# Altium schematic sheet Full-H Bridge_D.SchDoc("Full-H Bridge_D")
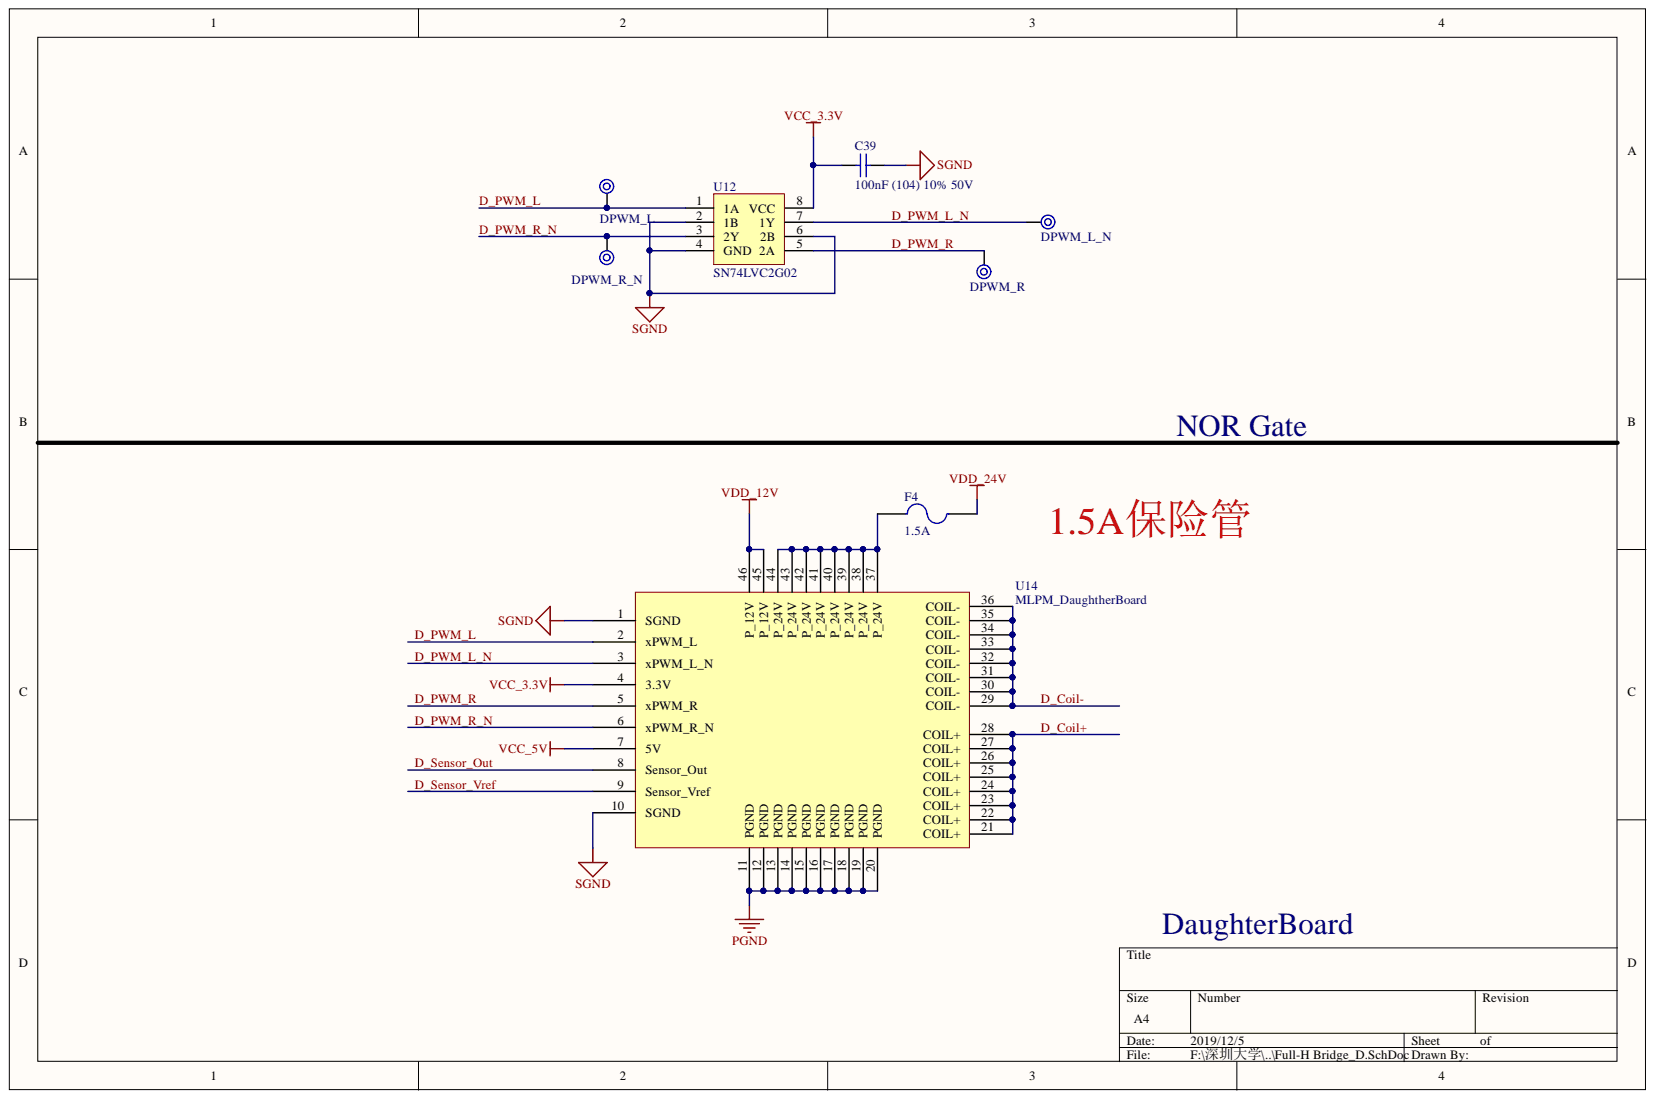
[diagram p0: Full-H Bridge_D.SchDoc]

## Parts
C39  100nF (104) 10% 50V  [0603_C]
DPWM_L  TP_Surface  [TEST_POINT_ME]  (value not drawn)
DPWM_L_N  TP_Surface  [TEST_POINT_ME]  (value not drawn)
DPWM_R  TP_Surface  [TEST_POINT_ME]  (value not drawn)
DPWM_R_N  TP_Surface  [TEST_POINT_ME]  (value not drawn)
F4  value 1.5A  [1812]  (hidden comment: Fuse)
U12  SN74LVC2G02  [SN74LVC2G02]
U14  MLPM_DaughtherBoard  [MLPM_DaughtherBoard]

## Nets
PGND: U14.11(PGND) U14.12(PGND) U14.13(PGND) U14.14(PGND) U14.15(PGND) U14.16(PGND) U14.17(PGND) U14.18(PGND) U14.19(PGND) U14.20(PGND)
SGND: C39.2 U12.2(1B) U12.4(GND) U12.6(2B) U14.1(SGND) U14.10(SGND)
VCC_3.3V: C39.1 U12.8(VCC) U14.4(3.3V)
VCC_5V: U14.7(5V)
VDD_12V: U14.45(P_12V) U14.46(P_12V)
VDD_24V: F4.2
D_Coil+: U14.21(COIL+) U14.22(COIL+) U14.23(COIL+) U14.24(COIL+) U14.25(COIL+) U14.26(COIL+) U14.27(COIL+) U14.28(COIL+)
D_Coil-: U14.29(COIL-) U14.30(COIL-) U14.31(COIL-) U14.32(COIL-) U14.33(COIL-) U14.34(COIL-) U14.35(COIL-) U14.36(COIL-)
D_PWM_L: DPWM_L.1 U12.1(1A) U14.2(xPWM_L)
D_PWM_L_N: DPWM_L_N.1 U12.7(1Y) U14.3(xPWM_L_N)
D_PWM_R: DPWM_R.1 U12.5(2A) U14.5(xPWM_R)
D_PWM_R_N: DPWM_R_N.1 U12.3(2Y) U14.6(xPWM_R_N)
D_Sensor_Out: U14.8(Sensor_Out)
D_Sensor_Vref: U14.9(Sensor_Vref)
NetF4_1: F4.1 U14.37(P_24V) U14.38(P_24V) U14.39(P_24V) U14.40(P_24V) U14.41(P_24V) U14.42(P_24V) U14.43(P_24V) U14.44(P_24V)

## Library data of the parts
C39 = 0603 100nF (104) 10% 50V: 贴片电容; SuppliersPartNumber: C14663; Supplier: LC; Package: 0603; Library Name: JLC Library.IntLib; ComponentLink1URL: http://www.szlcsc.com/product/details_15331.html; ComponentLink1Description: 供应商链接; Component Kind: Standard
F4 = Fuse 2: 保险丝; Published: 8-Jun-2000; PackageReference: PIN-W2/E2.8; LatestRevisionNote: Re-released for DXP Platform.; LatestRevisionDate: 17-Jul-2002; Component Kind: Standard; Publisher: Altium Limited; Library Name: 接插件集成库.IntLib
U12 = SN74LVC2G02: 2通道高速与非门; Component Kind: Standard; Library Name: PSRM_Sensorless_V2.0模块.IntLib
U14 = MLPM_Driver_DaugtherBoard: 磁悬浮平面电机电流驱动器子板; Component Kind: Standard; Library Name: MPLM_Driver_V10A1.IntLib
DPWM_L DPWM_L_N DPWM_R DPWM_R_N = TP_Surface: Component Kind: Standard; Library Name: PSRM_Sensorless_V2.0模块.IntLib
